# Altium schematic sheet MotorDriver_BrushedMotor_Communication.SchDoc
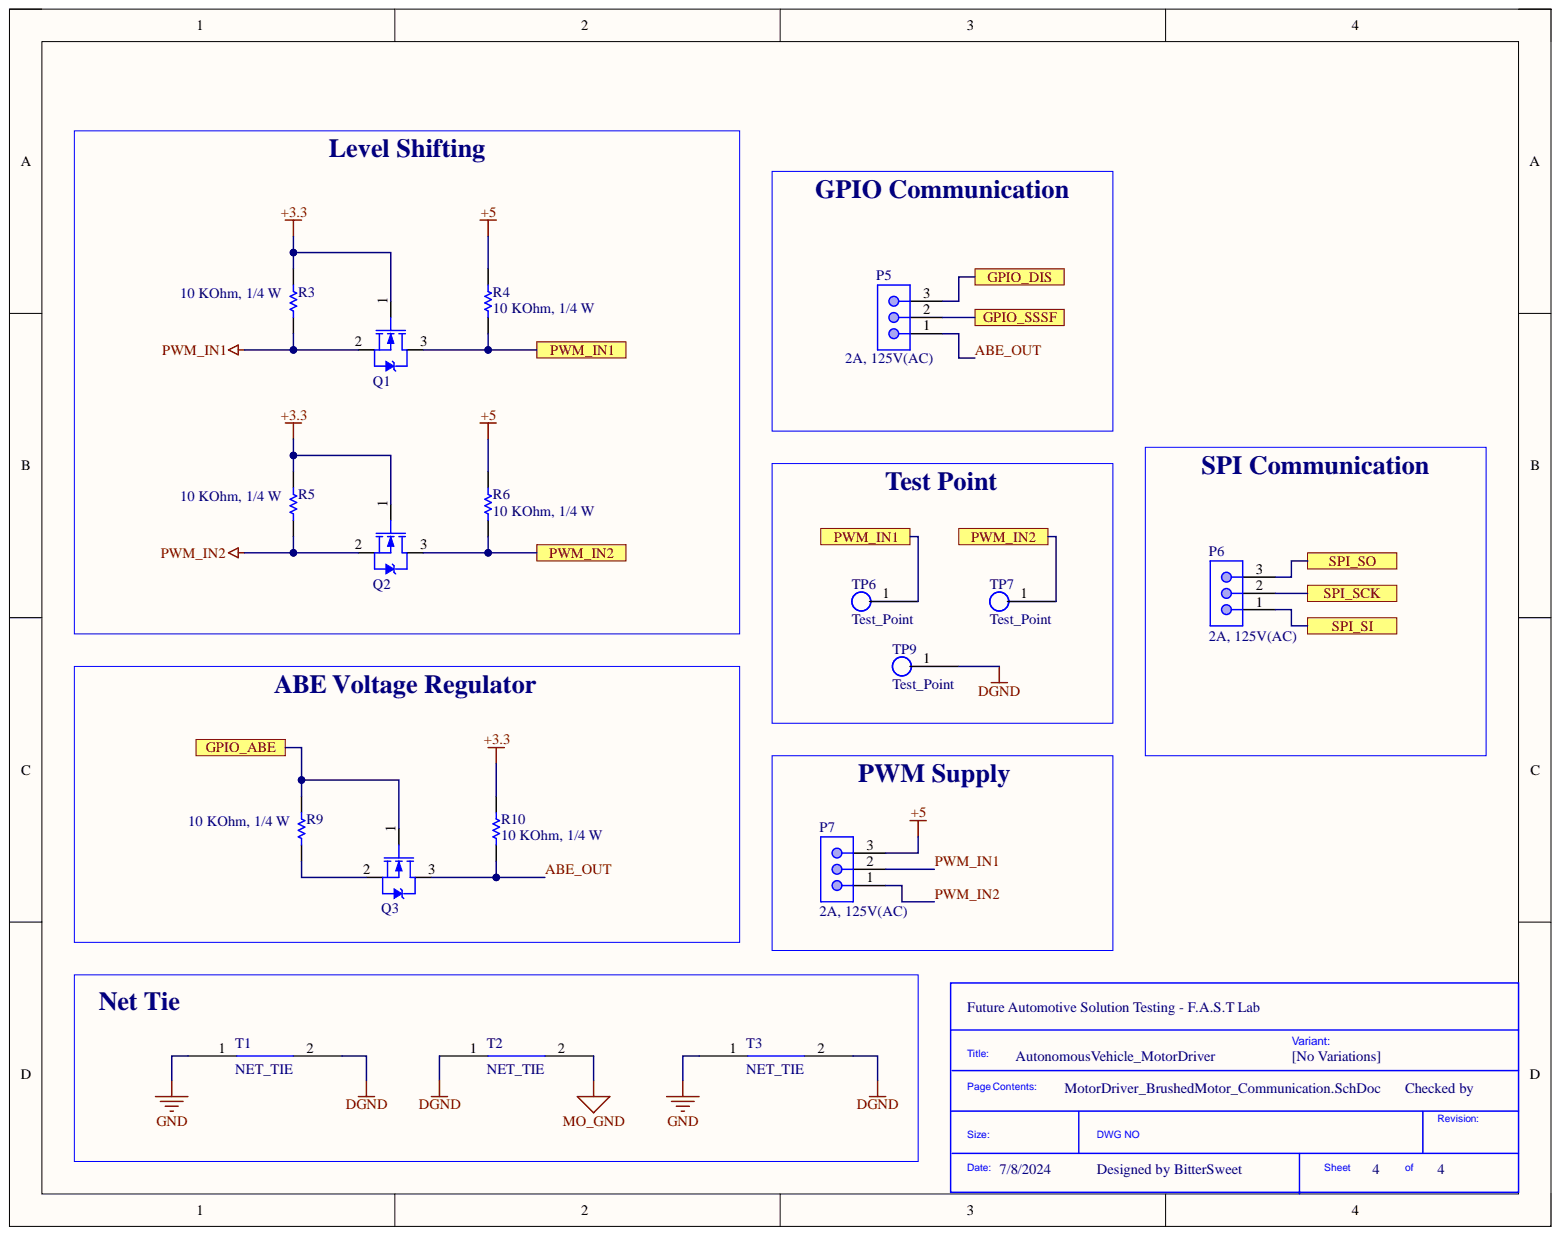
[diagram p0: MotorDriver_BrushedMotor_Communication.SchDoc]

## Parts
P5  2A, 125V(AC)  [620103131822]
P6  2A, 125V(AC)  [620103131822]
P7  2A, 125V(AC)  [620103131822]
Q1  Mosfet_N_channel  [MOSFET_N_SOT23-3]  (value not drawn)
Q2  Mosfet_N_channel  [MOSFET_N_SOT23-3]  (value not drawn)
Q3  Mosfet_N_channel  [MOSFET_N_SOT23-3]  (value not drawn)
R3  10 KOhm, 1/4 W  Rated Power: 1/4 W  [resistor_0603]
R4  10 KOhm, 1/4 W  [resistor_0603]
R5  10 KOhm, 1/4 W  Rated Power: 1/4 W  [resistor_0603]
R6  10 KOhm, 1/4 W  [resistor_0603]
R9  10 KOhm, 1/4 W  Rated Power: 1/4 W  [resistor_0603]
R10  10 KOhm, 1/4 W  [resistor_0603]
T1  NET_TIE  [NET_TIE_2.0mm]
T2  NET_TIE  [NET_TIE_2.0mm]
T3  NET_TIE  [NET_TIE_2.0mm]
TP6  Test_Point  [TP_1_5mm_SMD]
TP7  Test_Point  [TP_1_5mm_SMD]
TP9  Test_Point  [TP_1_5mm_SMD]

## Ports
GPIO_ABE, GPIO_DIS, GPIO_SSSF, PWM_IN1, PWM_IN2, SPI_SCK, SPI_SI, SPI_SO

## Nets
+3.3: Q1.1 Q2.1 R3.2 R5.2 R10.2
+5: P7.3 R4.2 R6.2
DGND: T1.2 T2.1 T3.2 TP9.1
GND: T1.1 T3.1
MO_GND: T2.2
ABE_OUT: P5.1 Q3.3 R10.1
GPIO_ABE: Q3.1 R9.2 ; port GPIO_ABE
GPIO_DIS: P5.3 ; port GPIO_DIS
GPIO_SSSF: P5.2 ; port GPIO_SSSF
PWM_IN1: P7.2 Q1.2 R3.1
PWM_IN2: P7.1 Q2.2 R5.1
SPI_SCK: P6.2 ; port SPI_SCK
SPI_SI: P6.1 ; port SPI_SI
SPI_SO: P6.3 ; port SPI_SO
NetQ1_3: Q1.3 R4.1 TP6.1 ; port PWM_IN1
NetQ2_3: Q2.3 R6.1 TP7.1 ; port PWM_IN2
NetQ3_2: Q3.2 R9.1

## Library data of the parts
P5-P7 = 620103131822: WR-WTB SMT Male Horizontal Shrouded Header, pitch 2mm, 3p; Contact Resistance: 20mOhm; Pin Number: 3; Withstanding Voltage: 800VAC; Working Voltage: 100VAC; Component Kind: Standard; ComponentLink1Description: Manufacturer; ComponentLink1URL: http://www.we-online.de; ComponentLink2Description: Datasheet; ComponentLink2URL: https://www.we-online.com/catalog/datasheet/620103131822.pdf; ComponentLink3Description: Global Supply; ComponentLink3URL: https://www.we-online.com/en/components/products/WTB_2_00_SMT_MALE_HORIZONTAL_SHROUDED_HEADER_6201XX131822?sq=620103131822#620103131822; ComponentLink4Description: Global Supply; ComponentLink4URL: https://www.mouser.vn/ProductDetail/Wurth-Elektronik/620103131822?qs=ZtY9WdtwX54jBicvPVEzcw%3D%3D; Gender: Male; Library Name: Altium_PCB_Connector.IntLib; Mounting Technology: SMT; Operating Temperature: -25 to +85degC; Packaging: Tape and Reel; Part Number: 620103131822; Pitch: 2mm; Published Date: January 2020; Rated Current: 2A; Supplier 1 Name: Mouser; Supplier 1 SN: 710-620103131822; Supplier 1 URL: https://www.mouser.vn/ProductDetail/Wurth-Elektronik/620103131822?qs=ZtY9WdtwX54jBicvPVEzcw%3D%3D; Supplier 2 Name: Digi-Key; Supplier 2 SN: N/A; Supplier 2 URL: N/A; Supplier 3 Name: thegioiic; Supplier 3 SN: N/A; Supplier 3 URL: N/A; Type: Horizontal; Value: 2A, 125V(AC); Publisher: Wurth Elektronik
R3 R5 R9 = 0006031031: RES, size 0603, 10 KOhm, 1/4 W; Manufacture  Name: OEM; Resistance: 10 KOhm; Resistance Tolerance: +/-1%; Component Kind: Standard; ComponentLink1Description: Datasheet link; ComponentLink1URL: https://fscdn.rohm.com/en/products/databook/datasheet/passive/resistor/chip_resistor/esr-e.pdf; ComponentLink2Description: Supplier 1 URL; ComponentLink2URL: https://www.thegioiic.com/dien-tro-10-kohm-0603-1-; ComponentLink3Description: Supplier 2 URL; ComponentLink3URL: https://www.digikey.com/en/products/detail/rohm-semiconductor/ESR03EZPF1002/1983429; ComponentLink4Description: Supplier 3 URL; ComponentLink4URL: N/A; Library Name: Altium_Resistor.IntLib; Manufacture  SN: OEM; Mounting Technology: SMT; Size: 0603; Supplier 1 Name: Mouser; Supplier 1 SN: 755-ESR03EZPF1002; Supplier 1 URL: https://www.mouser.vn/ProductDetail/ROHM-Semiconductor/ESR03EZPF1002?qs=sGAEpiMZZMvdGkrng054t67Efho1F8r6CDeAsqwFse8%3D; Supplier 2 Name: Digi-Key; Supplier 2 SN: RHM10KADTR-ND RHM10KADCT-ND RHM10KADDKR-ND; Supplier 2 URL: https://www.digikey.com/en/products/detail/rohm-semiconductor/ESR03EZPF1002/1983429; Supplier 3 Name: thegioiic; Supplier 3 SN: N/A; Supplier 3 URL: N/A; Value: 10 KOhm, 1/4 W
R4 R6 R10 = 0006031031: RES, size 0603, 10 KOhm, 1/4 W; Manufacture  Name: OEM; Rated Power: 1/4 W; Resistance: 10 KOhm; Resistance Tolerance: +/-1%; Component Kind: Standard; ComponentLink1Description: Datasheet link; ComponentLink1URL: https://fscdn.rohm.com/en/products/databook/datasheet/passive/resistor/chip_resistor/esr-e.pdf; ComponentLink2Description: Supplier 1 URL; ComponentLink2URL: https://www.thegioiic.com/dien-tro-10-kohm-0603-1-; ComponentLink3Description: Supplier 2 URL; ComponentLink3URL: https://www.digikey.com/en/products/detail/rohm-semiconductor/ESR03EZPF1002/1983429; ComponentLink4Description: Supplier 3 URL; ComponentLink4URL: N/A; Library Name: Altium_Resistor.IntLib; Manufacture  SN: OEM; Mounting Technology: SMT; Size: 0603; Supplier 1 Name: Mouser; Supplier 1 SN: 755-ESR03EZPF1002; Supplier 1 URL: https://www.mouser.vn/ProductDetail/ROHM-Semiconductor/ESR03EZPF1002?qs=sGAEpiMZZMvdGkrng054t67Efho1F8r6CDeAsqwFse8%3D; Supplier 2 Name: Digi-Key; Supplier 2 SN: RHM10KADTR-ND RHM10KADCT-ND RHM10KADDKR-ND; Supplier 2 URL: https://www.digikey.com/en/products/detail/rohm-semiconductor/ESR03EZPF1002/1983429; Supplier 3 Name: thegioiic; Supplier 3 SN: N/A; Supplier 3 URL: N/A; Value: 10 KOhm, 1/4 W
T1-T3 = NET_TIE: Straight Net Tie; Component Kind: Standard; Library Name: AutonomousVehicle_Lib.IntLib
Q1-Q3 = Mosfet_N_channel: Component Kind: Standard; Description: Mosfet_N_Channel; Library Name: AutonomousVehicle_Lib.IntLib
TP6 TP7 TP9 = Test_Point: Component Kind: Standard; Description: Test_Point; Library Name: AutonomousVehicle_Lib.IntLib
